# Altium schematic sheet LTC_Soft.SchDoc
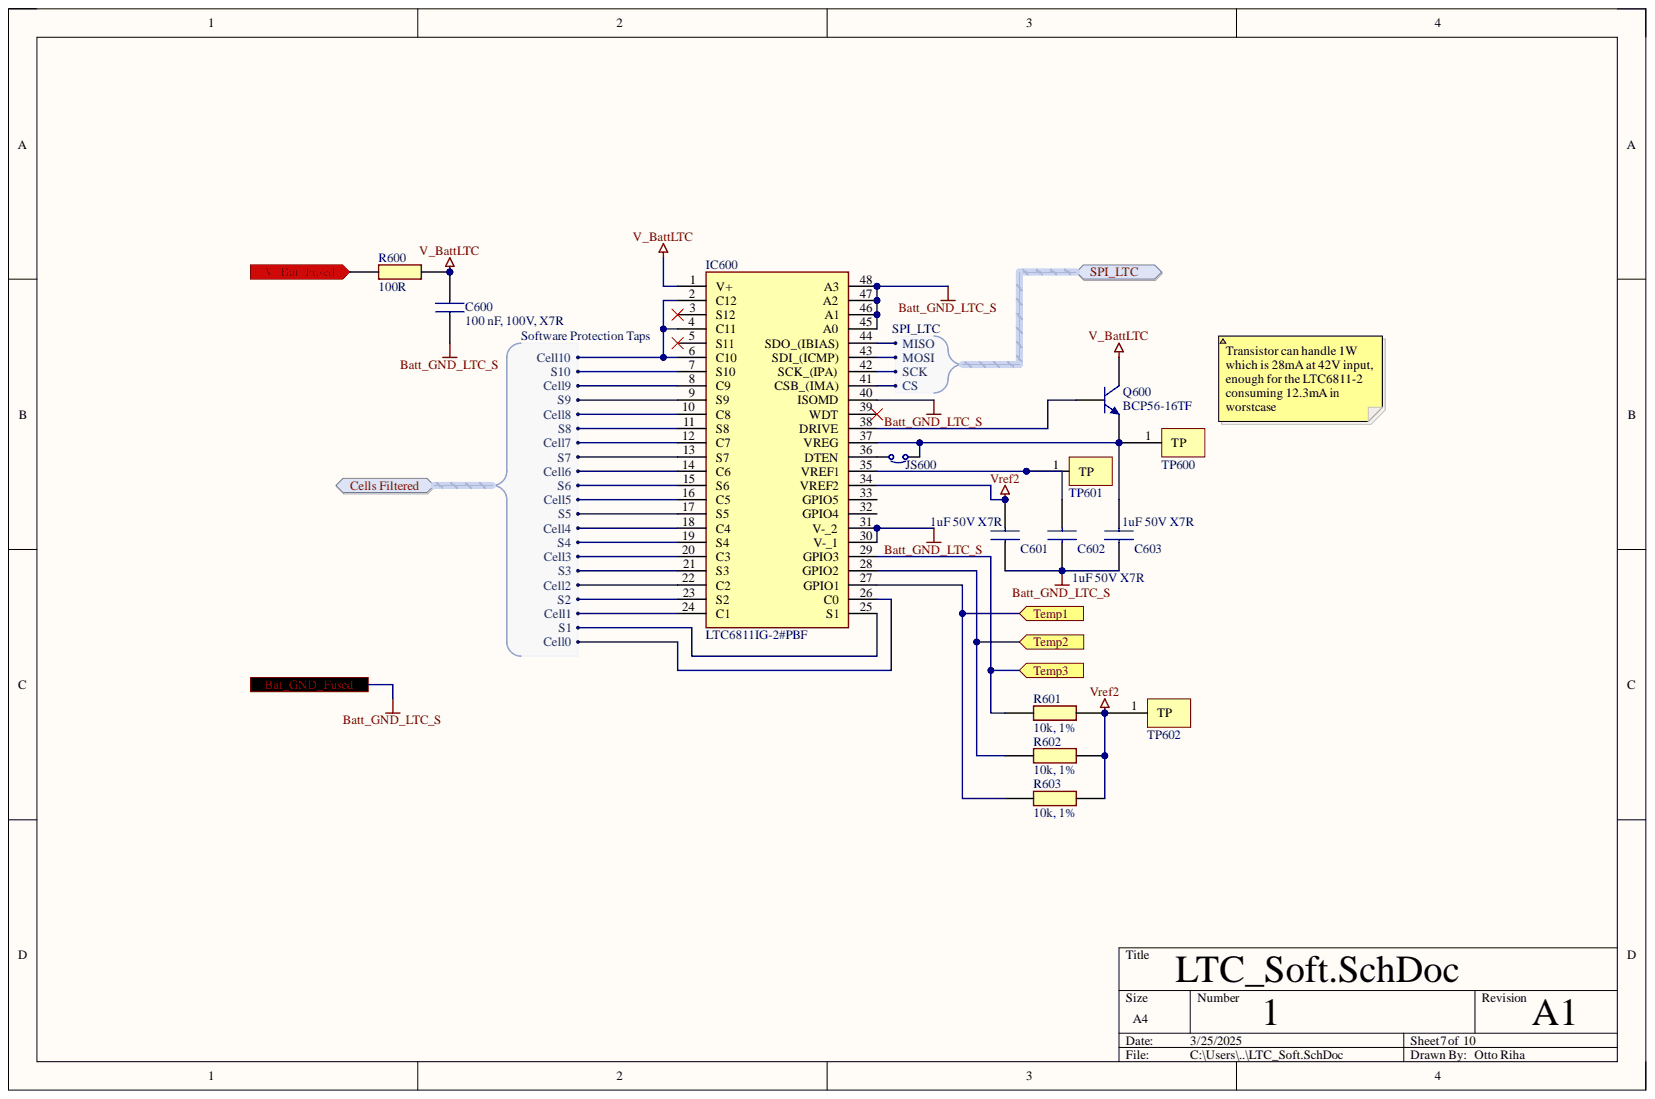
[diagram p0: LTC_Soft.SchDoc]

## Parts
C600  100 nF, 100V, X7R  [MAJx16]
C601  1uF 50V X7R  value 1uF  [CAPC1608X90N]
C602  1uF 50V X7R  value 1uF  [CAPC1608X90N]
C603  1uF 50V X7R  value 1uF  [CAPC1608X90N]
IC600  LTC6811IG-2#PBF  [SOP50P780X200-48N]
JS600  SolderJumper  [SolderJumper]  (value not drawn)
Q600  BCP56-16TF  [SOT230P700X180-4N]
R600  value 100R  [RESC1608X50N]  (hidden comment: CRCW0603100RFKEA)
R601  value 10k, 1%  [RESC1608X50N]  (hidden comment: CRCW060310K0FKEI)
R602  value 10k, 1%  [RESC1608X50N]  (hidden comment: CRCW060310K0FKEI)
R603  value 10k, 1%  [RESC1608X50N]  (hidden comment: CRCW060310K0FKEI)
TP600  Test Pad 1mm  [Test Pad 1mm-Footprint-1]  (value not drawn)
TP601  Test Pad 1mm  [Test Pad 1mm-Footprint-1]  (value not drawn)
TP602  Test Pad 1mm  [Test Pad 1mm-Footprint-1]  (value not drawn)

## Ports
Bat_GND_Fused, Cells Filtered, Cells Filtered.Cell0, Cells Filtered.Cell1, Cells Filtered.Cell2, Cells Filtered.Cell3, Cells Filtered.Cell4, Cells Filtered.Cell5, Cells Filtered.Cell6, Cells Filtered.Cell7, Cells Filtered.Cell8, Cells Filtered.Cell9, Cells Filtered.Cell10, Cells Filtered.S1, Cells Filtered.S2, Cells Filtered.S3, Cells Filtered.S4, Cells Filtered.S5, Cells Filtered.S6, Cells Filtered.S7, Cells Filtered.S8, Cells Filtered.S9, Cells Filtered.S10, SPI_LTC, SPI_LTC.CS, SPI_LTC.MISO, SPI_LTC.MOSI, SPI_LTC.SCK, Temp1, Temp2, Temp3, V_Bat_Fused

## Nets
V_BattLTC: C600.2 IC600.1(V+) Q600.2 R600.2
Vref2: C601.1 IC600.34(VREF2) R601.1 R602.1 R603.1 TP602.1
Batt_GND_LTC_S: C600.1 C601.2 C602.2 C603.2 IC600.30(V-_1) IC600.31(V-_2) IC600.40(ISOMD) IC600.45(A0) IC600.46(A1) IC600.47(A2) IC600.48(A3) ; port Bat_GND_Fused
Temp1: IC600.27(GPIO1) R603.2 ; port Temp1
Temp2: IC600.28(GPIO2) R602.2 ; port Temp2
Temp3: IC600.29(GPIO3) R601.2 ; port Temp3
V_Bat_Fused: R600.1 ; port V_Bat_Fused
NetC602_1: C602.1 IC600.35(VREF1) TP601.1
NetC603_1: C603.1 IC600.37(VREG) JS600.2 Q600.3 TP600.1
NetIC600_2: IC600.2(C12) IC600.4(C11) IC600.6(C10) ; port Cells Filtered
NetIC600_3: IC600.3(S12)
NetIC600_5: IC600.5(S11)
NetIC600_7: IC600.7(S10) ; port Cells Filtered
NetIC600_8: IC600.8(C9) ; port Cells Filtered
NetIC600_9: IC600.9(S9) ; port Cells Filtered
NetIC600_10: IC600.10(C8) ; port Cells Filtered
NetIC600_11: IC600.11(S8) ; port Cells Filtered
NetIC600_12: IC600.12(C7) ; port Cells Filtered
NetIC600_13: IC600.13(S7) ; port Cells Filtered
NetIC600_14: IC600.14(C6) ; port Cells Filtered
NetIC600_15: IC600.15(S6) ; port Cells Filtered
NetIC600_16: IC600.16(C5) ; port Cells Filtered
NetIC600_17: IC600.17(S5) ; port Cells Filtered
NetIC600_18: IC600.18(C4) ; port Cells Filtered
NetIC600_19: IC600.19(S4) ; port Cells Filtered
NetIC600_20: IC600.20(C3) ; port Cells Filtered
NetIC600_21: IC600.21(S3) ; port Cells Filtered
NetIC600_22: IC600.22(C2) ; port Cells Filtered
NetIC600_23: IC600.23(S2) ; port Cells Filtered
NetIC600_24: IC600.24(C1) ; port Cells Filtered
NetIC600_25: IC600.25(S1) ; port Cells Filtered
NetIC600_26: IC600.26(C0) ; port Cells Filtered
NetIC600_32: IC600.32(GPIO4)
NetIC600_33: IC600.33(GPIO5)
NetIC600_36: IC600.36(DTEN) JS600.1
NetIC600_38: IC600.38(DRIVE) Q600.1
NetIC600_39: IC600.39(WDT)
NetIC600_41: IC600.41(CSB_(IMA)) ; port SPI_LTC
NetIC600_42: IC600.42(SCK_(IPA)) ; port SPI_LTC
NetIC600_43: IC600.43(SDI_(ICMP)) ; port SPI_LTC
NetIC600_44: IC600.44(SDO_(IBIAS)) ; port SPI_LTC

## Library data of the parts
C600 = MAJCH168BB7104KTEA01: Capacitor 100nF, 100V, X7R; Manufacturer_Name: TAIYO YUDEN; Arrow Part Number: MAJCH168BB7104KTEA01; Arrow Price/Stock: https://www.arrow.com/en/products/majch168bb7104ktea01/taiyo-yuden?utm_currency=USD&region=nac; Component Kind: Standard; Datasheet Link: https://ds.yuden.co.jp/TYCOMPAS/or/detail?pn=MAJCH168BB7104KTEA01; Height: 0.8mm; Library Name: SamacSys.SchLib; Manufacturer_Part_Number: MAJCH168BB7104KTEA01; Mouser Part Number: 963-MAJCH168BB7104KT; Mouser Price/Stock: https://www.mouser.co.uk/ProductDetail/TAIYO-YUDEN/MAJCH168BB7104KTEA01?qs=ZcfC38r4PovaTBrG0IdezQ%3D%3D; Supplier 1: Mouser; Supplier Part Number 1: 963-MAJCH168BB7104KT
C601-C603 = 06035C105MAT2A: Capacitor 1uF 50V X7R; Manufacturer_Name: Kyocera AVX; Component Kind: Standard; Datasheet Link: https://componentsearchengine.com/Datasheets/1/06031A100FAT2A.pdf; Height: 0.9mm; Library Name: SamacSys.SchLib; Manufacturer_Part_Number: 06035C105MAT2A; Mouser Part Number: 581-06035C105MAT2A; Mouser Price/Stock: https://www.mouser.co.uk/ProductDetail/KYOCERA-AVX/06035C105MAT2A?qs=%252BdQmOuGyFcHMsevPtc6OZQ%3D%3D
IC600 = LTC6811IG-2#PBF: Integrated Circuit; Manufacturer_Name: Analog Devices; Arrow Part Number: LTC6811IG-2#PBF; Arrow Price/Stock: https://www.arrow.com/en/products/ltc6811ig-2pbf/analog-devices?region=nac; Component Kind: Standard; Datasheet Link: https://datasheet.datasheetarchive.com/originals/distributors/DKDS42/DSANUWW0041878.pdf; Height: 2mm; Manufacturer_Part_Number: LTC6811IG-2#PBF; Mouser Part Number: 584-LTC6811IG-2#PBF; Mouser Price/Stock: https://www.mouser.co.uk/ProductDetail/Analog-Devices/LTC6811IG-2PBF?qs=oahfZPh6IAI0fFYgAupCpg%3D%3D
Q600 = BCP56-16TF: Transistor BJT NPN; Manufacturer_Name: Nexperia; Arrow Part Number: BCP56-16TF; Arrow Price/Stock: https://www.arrow.com/en/products/bcp56-16tf/nexperia?region=nac; Component Kind: Standard; Datasheet Link: https://assets.nexperia.com/documents/data-sheet/BCP56T_SER.pdf; Height: 1.8mm; Library Name: SamacSys.SchLib; Manufacturer_Part_Number: BCP56-16TF; Mouser Part Number: 771-BCP56-16TF; Mouser Price/Stock: https://www.mouser.co.uk/ProductDetail/Nexperia/BCP56-16TF?qs=uj7TeyS2Sj96ubfysKmpcg%3D%3D
R600 = CRCW0603100RFKEA: Resistor 100R, 1%; Manufacturer_Name: Vishay; Arrow Part Number: CRCW0603100RFKEA; Arrow Price/Stock: https://www.arrow.com/en/products/crcw0603100rfkea/vishay?utm_currency=USD&region=europe; Component Kind: Standard; Datasheet Link: http://www.vishay.com/docs/20035/dcrcwe3.pdf; Height: 0.5mm; Library Name: SamacSys.SchLib; Manufacturer_Part_Number: CRCW0603100RFKEA; Mouser Part Number: 71-CRCW0603-100-E3; Mouser Price/Stock: https://www.mouser.co.uk/ProductDetail/Vishay-Dale/CRCW0603100RFKEA?qs=90AHS1y%2FsidGWjjxZAupKg%3D%3D; Supplier 1: Mouser; Supplier Part Number 1: 71-CRCW0603-100-E3
R601-R603 = CRCW060310K0FKEI: Resistor 10k, 1%; Manufacturer_Name: Vishay; Arrow Part Number: CRCW060310K0FKEI; Arrow Price/Stock: https://www.arrow.com/en/products/crcw060310k0fkei/vishay?utm_currency=USD&region=nac; Component Kind: Standard; Datasheet Link: http://www.vishay.com/docs/20035/dcrcwe3.pdf; Height: 0.5mm; Library Name: SamacSys.SchLib; Manufacturer_Part_Number: CRCW060310K0FKEI; Mouser Part Number: 71-CRCW060310K0FKEI; Mouser Price/Stock: https://www.mouser.co.uk/ProductDetail/Vishay-Dale/CRCW060310K0FKEI?qs=3qWEzBg5KnKofE%252B8acPBRA%3D%3D; Supplier 1: Mouser; Supplier Part Number 1: 71-CRCW060310K0FKEI
TP600-TP602 = CMP-002-00031-3: 1mm radius circuilar test pad; Component Kind: Standard; Library Name: EPFL Racing Team
JS600 = SolderJumper: Component Kind: Standard; Library Name: SamacSys.SchLib
